# Altium schematic sheet Slave.SchDoc(Slave)
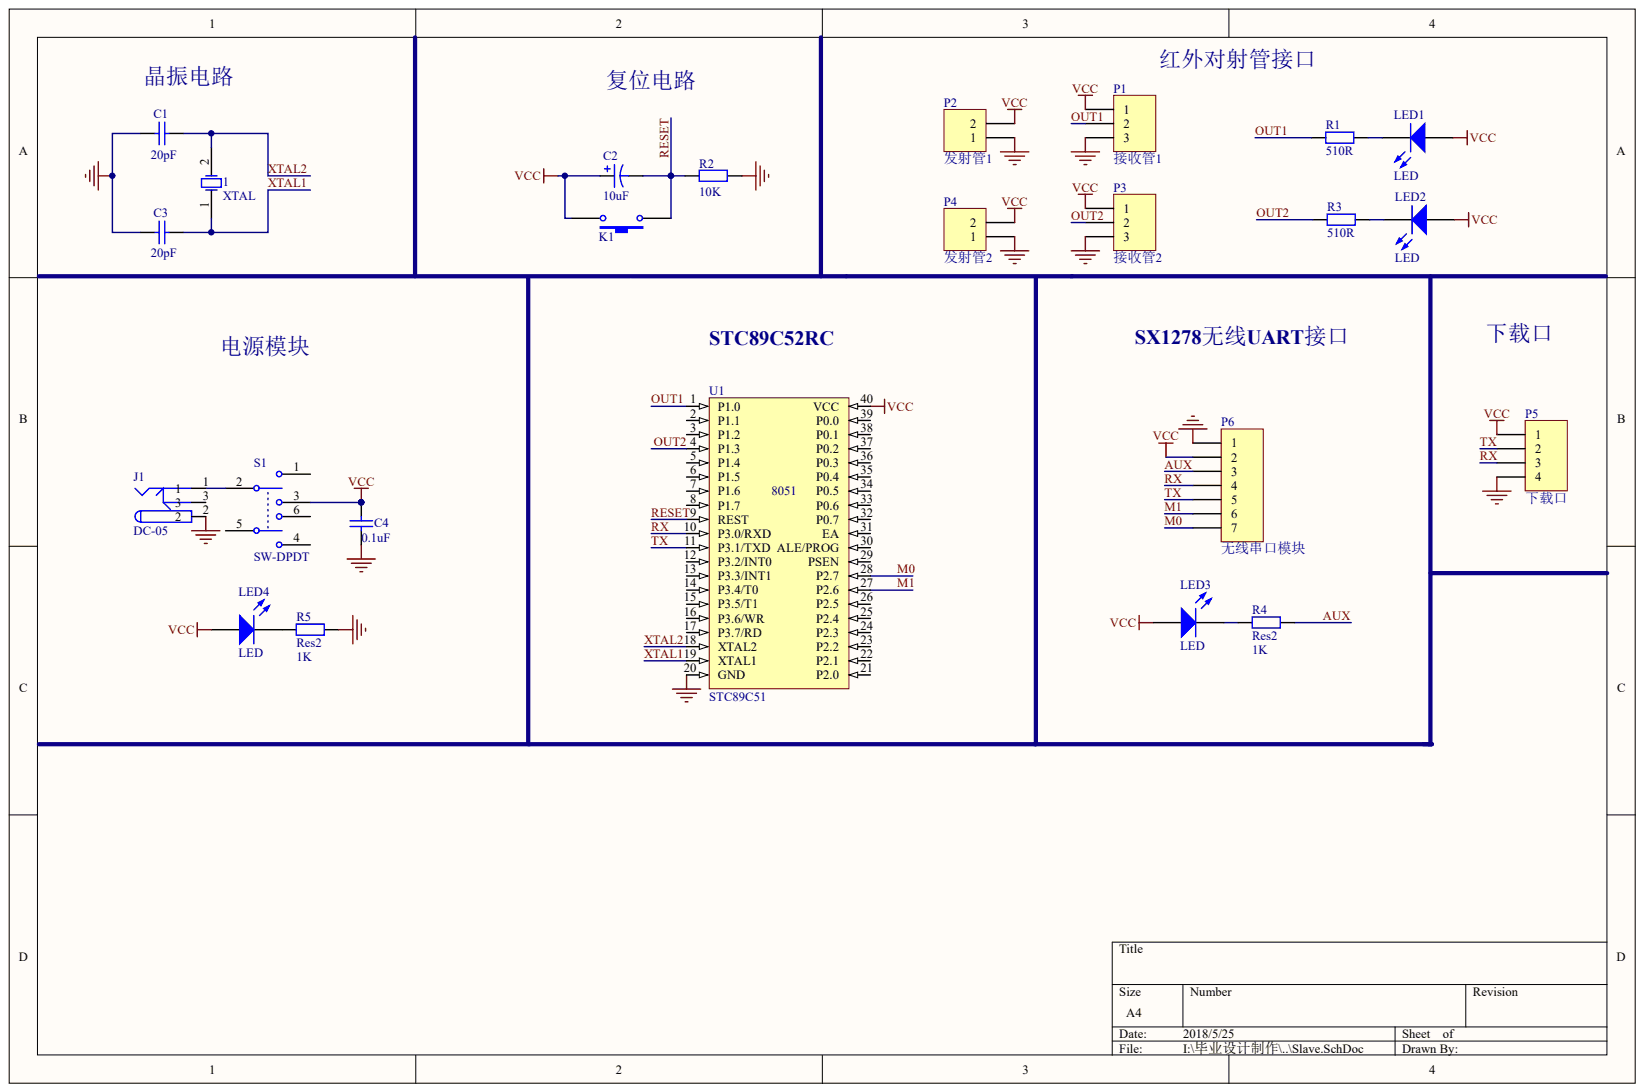
[diagram p0: Slave.SchDoc]

## Parts
1  XTAL
C1  value 20pF  (hidden comment: Cap)
C2  value 10uF  (hidden comment: Cap Pol1)
C3  value 20pF  (hidden comment: Cap)
C4  value 0.1uF  (hidden comment: Cap)
J1  DC-05
K1  四脚按键  (value not drawn)
LED1  LED
LED2  LED
LED3  LED
LED4  LED
P1  接收管1
P2  发射管1
P3  接收管2
P4  发射管2
P5  下载口
P6  无线串口模块
R1  value 510R  (hidden comment: Res2)
R2  value 10K  (hidden comment: Res2)
R3  value 510R  (hidden comment: Res2)
R4  Res2  value 1K
R5  Res2  value 1K
S1  SW-DPDT
U1  STC89C51

## Nets
GND: C1.1 C3.1 C4.1 J1.2 P1.3 P2.1 P3.3 P4.1 P5.4 P6.1 R2.2 R5.2 U1.20(GND)
VCC: C2.1 C4.2 K1.2 LED1.1 LED2.1 LED3.1 LED4.1 P1.1 P2.2 P3.1 P4.2 P5.1 P6.2 S1.3 U1.40(VCC)
AUX: P6.3 R4.2
M0: P6.7 U1.28(P2.7)
M1: P6.6 U1.27(P2.6)
OUT1: P1.2 R1.1 U1.1(P1.0)
OUT2: P3.2 R3.1 U1.4(P1.3)
RESET: C2.2 K1.1 R2.1 U1.9(REST)
RX: P5.3 P6.4 U1.10(P3.0/RXD)
TX: P5.2 P6.5 U1.11(P3.1/TXD)
XTAL1: 1.1 C3.2 U1.19(XTAL1)
XTAL2: 1.2 C1.2 U1.18(XTAL2)
NetJ1_1: J1.1 S1.2
NetLED1_2: LED1.2 R1.2
NetLED2_2: LED2.2 R3.2
NetLED3_2: LED3.2 R4.1
NetLED4_2: LED4.2 R5.1

Not connected: J1 3; S1 1 4 5 6; U1 2(P1.1) 3(P1.2) 5(P1.4) 6(P1.5) 7(P1.6) 8(P1.7) 12(P3.2/INT0) 13(P3.3/INT1) 14(P3.4/T0) 15(P3.5/T1) 16(P3.6/WR) 17(P3.7/RD) 21(P2.0) 22(P2.1) 23(P2.2) 24(P2.3) 25(P2.4) 26(P2.5) 29(PSEN) 30(ALE/PROG) 31(EA) 32(P0.7) 33(P0.6) 34(P0.5) 35(P0.4) 36(P0.3) 37(P0.2) 38(P0.1) 39(P0.0)

## Library data of the parts
1 C1-C4 J1 K1 LED1-LED4 P1-P6 R1-R5 S1 U1 = (no symbol name)
  1: Published: 8-Jun-2000; LatestRevisionDate: 17-Jul-2002; LatestRevisionNote: Re-released for DXP Platform.; PackageReference: R38; PackageDescription: Crystal, Thru-Hole; 2 Leads; Body 3.1 x 8.2 mm (Dia.xH)
  C1 C3 C4: Published: 8-Jun-2000; LatestRevisionDate: 17-Jul-2002; LatestRevisionNote: Re-released for DXP Platform.; PackageReference: RAD-0.3; PackageDescription: Radial Cap, Thru-Hole; 2 Leads; 0.3 in Pin Spacing
  C2: Published: 8-Jun-2000; LatestRevisionDate: 17-Jul-2002; LatestRevisionNote: Re-released for DXP Platform.; PackageReference: RB7.6-15; PackageDescription: Polarized Capacitor (Radial); 2 Leads
  P1-P6: LatestRevisionDate: 17-Jul-2002; LatestRevisionNote: Re-released for DXP Platform.
  R1-R5: Published: 8-Jun-2000; LatestRevisionDate: 17-Jul-2002; LatestRevisionNote: Re-released for DXP Platform.; PackageReference: AXIAL-0.4; PackageDescription: Axial Device, Thru-Hole; 2 Leads; 0.4 in Pin Spacing
  S1: Published: 24-Mar-1999; LatestRevisionDate: 17-Jul-2002; LatestRevisionNote: Re-released for DXP Platform.; PackageReference: DPDT-6
  1 C1-C4 P1-P6 R1-R5 S1: Publisher: Altium Limited
